# Altium schematic sheet MCU.SchDoc(MCU)
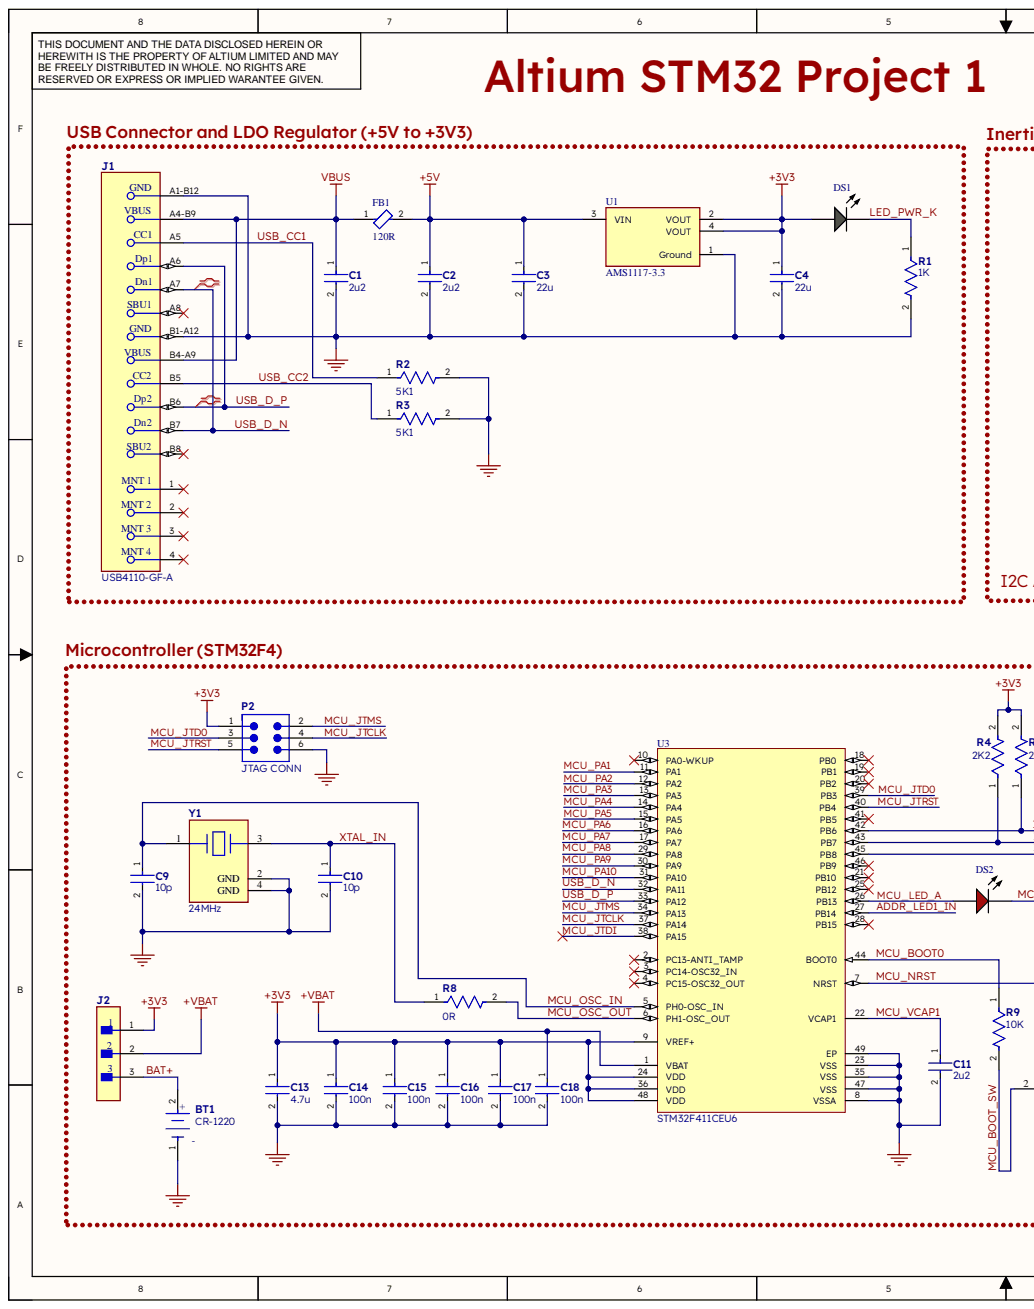
[diagram p0: MCU.SchDoc, left]
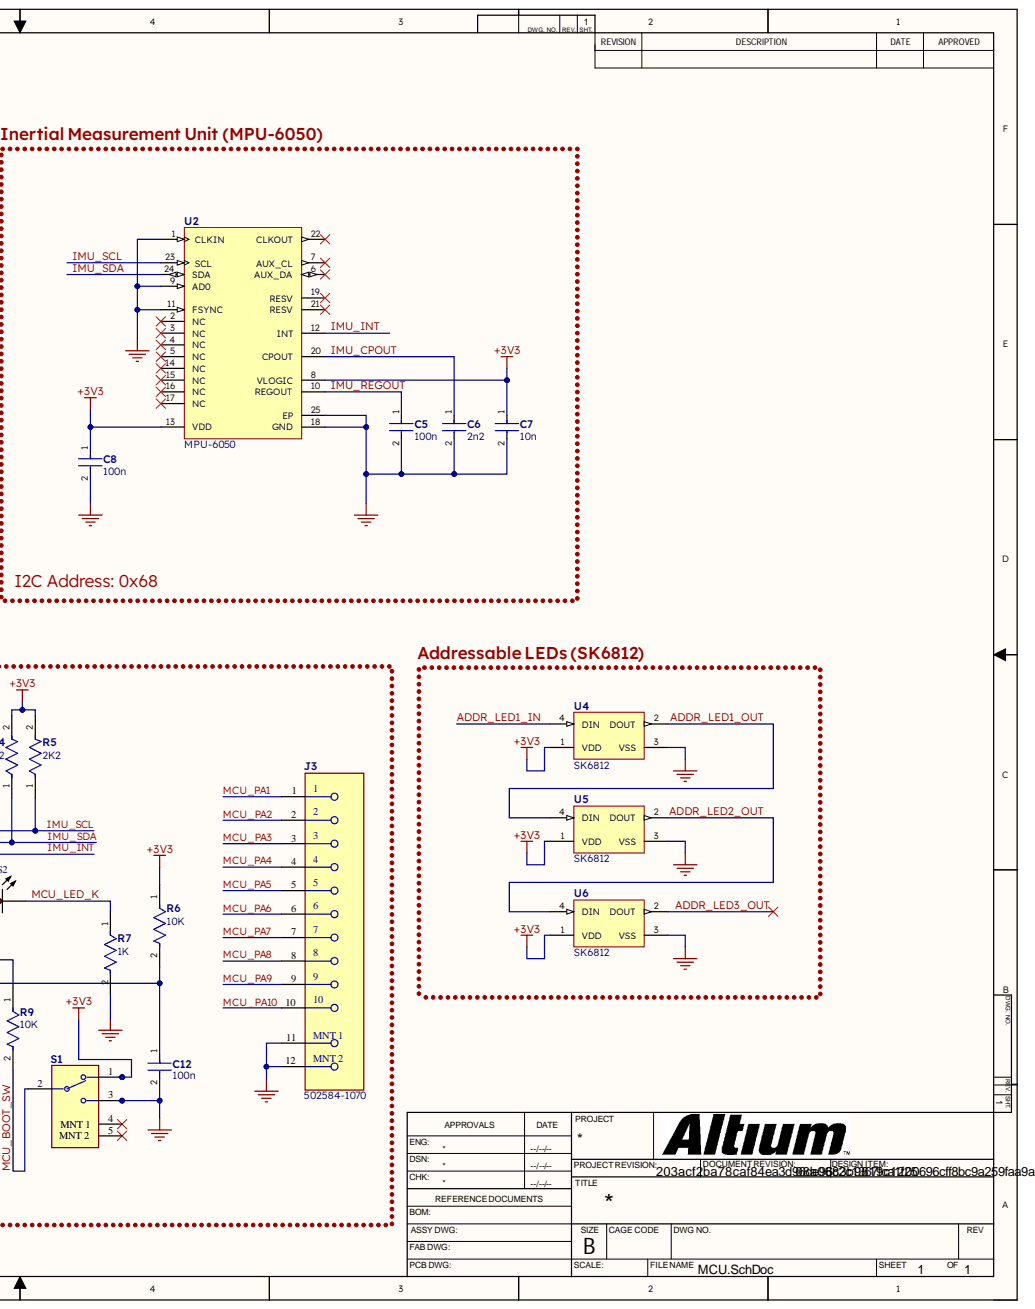
[diagram p1: MCU.SchDoc, right]

## Parts
BT1  CR-1220  [FP-S8411-45R-MFG]
C1  2u2  [FP-0805-L_2_01_0_2-W_1_25-IPC_B]
C2  2u2  [FP-0805-L_2_01_0_2-W_1_25-IPC_B]
C3  22u  [FP-CC0805-0_85-MFG]
C4  22u  [FP-CC0805-0_85-MFG]
C5  100n  [FP-CC0805-0_85-MFG]
C6  2n2  [FP-0805-L_2_01_0_2-W_1_25-IPC_B]
C7  10n  [FP-CC0805-0_85-MFG]
C8  100n  [FP-CC0805-0_85-MFG]
C9  10p  [FP-CC0805-0_85-MFG]
C10  10p  [FP-CC0805-0_85-MFG]
C11  2u2  [FP-0805-L_2_01_0_2-W_1_25-IPC_B]
C12  100n  [FP-CC0805-0_85-MFG]
C13  4.7u  [FP-0805-L_2_01_0_2-W_1_25-MFG]
C14  100n  [FP-CC0805-0_85-MFG]
C15  100n  [FP-CC0805-0_85-MFG]
C16  100n  [FP-CC0805-0_85-MFG]
C17  100n  [FP-CC0805-0_85-MFG]
C18  100n  [FP-CC0805-0_85-MFG]
DS1  1K  [AVAG-HSMX-C170_V]  (value not drawn)
DS2  LTST-C170KRKT  [LTST-C170KRKT_V]  (value not drawn)
FB1  120R  [FP-BLM21-0_2-t0_85-e0_5-IPC_A]
J1  USB4110-GF-A  [FP-USB4110-GF-A-MFG]
J2  5-146128-1  [FP-5-146128-1-MFG]  (value not drawn)
J3  502584-1070  [FP-502584-1070-MFG]
P2  JTAG CONN  [61000621121]
R1  1K  [FP-CRCW0805-e3-IPC_A]
R2  5K1  [FP-CRCW0805-e3-IPC_A]
R3  5K1  [FP-CRCW0805-e3-IPC_A]
R4  2K2  [FP-CRCW0805-e3-IPC_A]
R5  2K2  [FP-CRCW0805-e3-IPC_A]
R6  10K  [FP-CRCW0805-e3-IPC_A]
R7  1K  [FP-CRCW0805-e3-IPC_A]
R8  0R  [FP-CRCW0805-e3-IPC_A]
R9  10K  [FP-CRCW0805-e3-IPC_A]
S1  SS312SAH4-R  [FP-SS312SAH4-R-MFG]  (value not drawn)
U1  AMS1117-3.3  [FP-AMS1117-IPC_C]
U2  MPU-6050  [IS-QFN-24_V]
U3  STM32F411CEU6  [STM-UFQFPN48_L]
U4  SK6812  [ADA-RGB-1655_V]
U5  SK6812  [ADA-RGB-1655_V]
U6  SK6812  [ADA-RGB-1655_V]
Y1  24MHz  [FP-ABM8G-MFG]

## Nets
+3V3: C4.1 C7.1 C8.1 C13.1 C14.1 C15.1 C16.1 C17.1 DS1.1 J2.1 P2.1 R4.2 R5.2 R6.1 S1.1 U1.2(VOUT) U1.4(VOUT) U2.8(VLOGIC) U2.13(VDD) U3.9(VREF+) U3.24(VDD) U3.36(VDD) U3.48(VDD) U4.1(VDD) U5.1(VDD) U6.1(VDD)
+5V: C2.1 C3.1 FB1.2 U1.3(VIN)
+VBAT: C18.1 J2.2 U3.1(VBAT)
GND: BT1.1 C1.2 C2.2 C3.2 C4.2 C5.2 C6.2 C7.2 C8.2 C9.2 C10.2 C11.2 C12.2 C13.2 C14.2 C15.2 C16.2 C17.2 C18.2 J1.A1-B12 J1.B1-A12 J3.11 J3.12 P2.6 R1.2 R2.2 R3.2 R7.2 S1.3 U1.1(Ground) U2.1(CLKIN) U2.9(AD0) U2.11(FSYNC) U2.18(GND) U2.25(EP) U3.8(VSSA) U3.23(VSS) U3.35(VSS) U3.47(VSS) U3.49(EP) U4.3(VSS) U5.3(VSS) U6.3(VSS) Y1.2(GND) Y1.4(GND)
VBUS: C1.1 FB1.1 J1.A4-B9 J1.B4-A9
ADDR_LED1_IN: U3.27(PB14) U4.4(DIN)
ADDR_LED1_OUT: U4.2(DOUT) U5.4(DIN)
ADDR_LED2_OUT: U5.2(DOUT) U6.4(DIN)
ADDR_LED3_OUT: U6.2(DOUT)
BAT+: BT1.2 J2.3
IMU_CPOUT: C6.1 U2.20(CPOUT)
IMU_INT: U2.12(INT) U3.45(PB8)
IMU_REGOUT: C5.1 U2.10(REGOUT)
IMU_SCL: R5.1 U2.23(SCL) U3.42(PB6)
IMU_SDA: R4.1 U2.24(SDA) U3.43(PB7)
LED_PWR_K: DS1.2 R1.1
MCU_BOOT0: R9.1 U3.44(BOOT0)
MCU_BOOT_SW: R9.2 S1.2
MCU_JTCLK: P2.4 U3.37(PA14)
MCU_JTD0: P2.3 U3.39(PB3)
MCU_JTDI: U3.38(PA15)
MCU_JTMS: P2.2 U3.34(PA13)
MCU_JTRST: P2.5 U3.40(PB4)
MCU_LED_A: DS2.1 U3.26(PB13)
MCU_LED_K: DS2.2 R7.1
MCU_NRST: C12.1 R6.2 U3.7(NRST)
MCU_OSC_IN: C9.1 U3.5(PH0-OSC_IN) Y1.1
MCU_OSC_OUT: R8.2 U3.6(PH1-OSC_OUT)
MCU_PA1: J3.1 U3.11(PA1)
MCU_PA2: J3.2 U3.12(PA2)
MCU_PA3: J3.3 U3.13(PA3)
MCU_PA4: J3.4 U3.14(PA4)
MCU_PA5: J3.5 U3.15(PA5)
MCU_PA6: J3.6 U3.16(PA6)
MCU_PA7: J3.7 U3.17(PA7)
MCU_PA8: J3.8 U3.29(PA8)
MCU_PA9: J3.9 U3.30(PA9)
MCU_PA10: J3.10 U3.31(PA10)
MCU_VCAP1: C11.1 U3.22(VCAP1)
USB_CC1: J1.A5 R2.1
USB_CC2: J1.B5 R3.1
USB_D_N: J1.A7 J1.B7 U3.32(PA11)
USB_D_P: J1.A6 J1.B6 U3.33(PA12)
XTAL_IN: C10.1 R8.1 Y1.3

Not connected: J1 1 2 3 4 A8 B8; S1 4(MNT_1) 5(MNT_2); U2 2(NC) 3(NC) 4(NC) 5(NC) 6(AUX_DA) 7(AUX_CL) 14(NC) 15(NC) 16(NC) 17(NC) 19(RESV) 21(RESV) 22(CLKOUT); U3 2(PC13-ANTI_TAMP) 3(PC14-OSC32_IN) 4(PC15-OSC32_OUT) 10(PA0-WKUP) 18(PB0) 19(PB1) 20(PB2) 21(PB10) 25(PB12) 28(PB15) 41(PB5) 46(PB9)

## Library data of the parts
BT1 = CMP-15817-000007-1: BATTERY HOLDER COIN 12MM SMD; Number of Batteries: 1; Case/Package: SMD/SMT; Component Kind: Standard; Contact Plating: Bronze; Library Name: Altium Content Vault; Material: Polyphenylene; Max Operating Temperature: 105°C; Min Operating Temperature: -55°C; Mount: Surface Mount; Number of Cells: 1; Package Quantity: 500; Packaging: Tape and Reel; REACH SVHC: No SVHC; Termination: SMD/SMT
C1 C2 C6 C11 = CMP-2000-05810-2: General Purpose Ceramic Capacitor, 0805, 2.2uF, 10%, X5R, 15%, 10V; Dissipation Factor: 5%; Insulation Resistance: 500MR; Capacitance: 2.2uF; Case Code (Imperial): 0805; Case Code (Metric): 2012; Case/Package: 0805; Component Kind: Standard; Construction: Flat; Contact Plating: Tin; Depth: 1.25mm; Dielectric: X5R; Dielectric Material: Ceramic; Height: 1.4mm; Lead Free: Lead Free; Length: 2.01mm; Library Name: Altium Content Vault; Max Operating Temperature: 85°C; Min Operating Temperature: -55°C; Mount: Surface Mount; Number of Pins: 2; Package Quantity: 1; Packaging: Tape and Reel; Radiation Hardening: No; REACH SVHC: No SVHC; RoHS Compliant: Yes; Series: -; Size: 1.4mm; Termination: SMD/SMT; Thickness: 0.055inch; Tolerance: 10%; Voltage: 10V; Voltage Rating: 10V; Voltage Rating (DC): 10V; Width: 0.049inch
C3-C5 C7-C10 C12 C14-C18 = CMP-1036-04402-6: CAP CER 0.1UF 16V X7R 0805; Manufacturer: Yageo; Manufacturer Part Number: CC0805KRX7R7BB104; Capacitance: 100 nF; Material: Ceramic; Tolerance: 0.1; Value: 100 nF; Automotive: No; Category: Cap; Component Kind: Standard; ComponentLink2Description: Datasheet; ComponentLink2URL: http://www.yageo.com/documents/recent/UPY-GPHC_Y5V_6.3V-to-50V_8.pdf; Device Class L1: Passive Components; Device Class L2: Capacitors; Device Class L3: Ceramic Capacitors; Digikey Description: CAP CER 0.1UF 16V X7R 0805; Footprint Url: http://www.yageo.com/exep/pages/download/literatures/UPY-C_GEN_24.pdf; Height: 0.95mm; Ipc Land Pattern Name: CAPC200125X85; Lead Free: Yes; Library Name: Altium Content Vault; Mouser Description: Multilayer Ceramic Capacitors MLCC - SMD/SMT 100nF 16V X7R 10%; Octopart: https://octopart.com/cc0805krx7r7bb104-yageo-39467769; Package: CC0805-0.85; RoHS: TRUE; Temperature: -30°C to +85°C; Temperature Characteristic: X7R; Temperature Range High: +85°C; Temperature Range Low: -30°C; Voltage Rating: 16 V
C13 = CMP-2007-04518-2: General Purpose Ceramic Capacitor, 0805, 4.7uF, 10%, X7R, 15%, 16V; Dissipation Factor: 3.5%; Voltage: 10V; Capacitance: 4.7uF; Case Code (Imperial): 0805; Case Code (Metric): 2012; Case/Package: 0805; Component Kind: Standard; Construction: Flat; Contact Plating: Tin; Depth: 1.25mm; Dielectric: X7R; Height: 1.52mm; Lead Free: Lead Free; Length: 2.01mm; Library Name: Altium Content Vault; Max Operating Temperature: 125°C; Min Operating Temperature: -55°C; Mount: Surface Mount; Number of Pins: 2; Package Quantity: 1; Packaging: Tape and Reel; Radiation Hardening: No; REACH SVHC: No SVHC; RoHS Compliant: Yes; Series: -; Termination: SMD/SMT; Thickness: 0.06inch; Tolerance: 10%; Voltage Rating: 16V; Voltage Rating (DC): 16V; Width: 0.049inch
DS1 = CMP-2000-05062-1: Chip LED, Green, 2.2 V, -40 to 85 degC, 2-Pin SMD, Pb-Free, Tape and Reel; Manufacturer: Avago; Bulb Size: 1.25mmx1.4mm; Dominant Wavelength: 572nm; External Depth: 0.8mm; External Length / Height: 2mm; External Width: 1.25mm; Forward Current If: 20mA; Forward Voltage: 2.2V; Forward Voltage VF Max: 2.6V; LED Type: Standard; Lens Shape: Rectangular; Light Area Height: 1mm; Luminous Intensity: 15mcd; Luminous Intensity Min: 4mcd; No. of Pins: 2; Opto Mounting Orientation: Top; Peak Wavelength: 570nm; PIV Max: 5V; SVHC: NoSVHC(16-Jun-2014); Viewing Angle: 170deg; Component Kind: Standard; Datasheet URL: https://datasheet.ciiva.com/29280/180495-da-01-en-chip-led-hsmg-c170-gelb-gruen-29280971.pdf; Datasheet Version: Oct-08; Dominant Wavelength Typ: 572nm; Forward Current If Max: 20mA; LED Colour: Green; LED Mounting: SMD; Library Name: Altium Content Vault; Luminous Intensity Typ: 15mcd; Manufacturer URL: http://www.avagotech.com/; Mounting Type: SMD; MSL: MSL2A-4weeks; Operating Temperature Max: 85degC; Operating Temperature Min: -30degC; Operating Temperature Range: -30degCto+85degC; Opto Case Style: SMD; Package Description: 2-Pin Surface Mount Device, Body 2 x 1.25 mm, Height 0.8 mm; Package Reference: HSMX-C170; Packaging: CutTape; Peak Forward Current: 20mA; Storage Temperature Max: 85degC; Storage Temperature Min: -40degC; Voltage Vf Typ: 2.2V; Wavelength Typ: 570nm
DS2 = CMP-2000-07593-1: LED Red, 75 mW, 54 mcd, -55 to 85 degC, 2-Pin SMD, RoHS, Tape and Reel; Manufacturer: Lite-On; Case\/Package: 0805; Dominant Wavelength: 631nm; Forward Current: 30mA; Forward Voltage: 2V; Lens Colour: Colorless; Lens Style: Rectangular; Lens Transparency: Clear; Luminous Intensity: 54mcd; Number of Elements: 1; Power Dissipation: 75mW; Test Current: 20mA; Viewing Angle: 130degrees; Wavelength: 639nm; Case Code (Imperial): 0805; Case Code (Metric): 2012; Colour: Red; Component Kind: Standard; Datasheet URL: http://optoelectronics.liteon.com/upload/download/DS-22-99-0150/LTST-C170KRKT.pdf; Datasheet Version: Rev. D, 11/2014; Depth: 1.6mm; Height: 1.1mm; Illumination Colour: Red; Lead Free: LeadFree; Length: 3.2mm; Library Name: Altium Content Vault; Manufacturer URL: http://www.us.liteon.com/; Max Operating Temperature: 85degC; Min Operating Temperature: -55degC; Mount: SurfaceMount; Mounting Technology: SurfaceMount; Number of LEDs: 1; Number of Pins: 2; Package Description: 2-Pin Surface Mount Device, Body 2 x 1.25 mm; Package Quantity: 1; Package Reference: C170KRKT; Packaging: TapeandReel; Power Rating: 75mW; Radiation Hardening: No; RoHSCompliant: True; Width: 1.25mm
FB1 = CMP-0686-00716-3: FERRITE BEAD 120 OHM 0805 1LN; Current Rating: 800mA; DC Current: 200mA; DC Resistance (DCR): 100mR; Frequency: 100MHz; Material: Steel; Max Current Rating: 1A; Number of Circuits: 1; Resistance: 150mR; Shielding: Unshielded; Tolerance: 25%; Case Code (Imperial): 0805; Case Code (Metric): 2012; Case/Package: 0805; Component Kind: Standard; Core Material: Ferrite; DC Resistance-Max: 100mR; Depth: 1.25mm; Height: 0.85mm; Impedance: 120R; Lead Free: Lead Free; Length: 2mm; Library Name: Altium Content Vault; Max DC Current: 200mA; Max Operating Temperature: 125°C; Military Standard: Not; Min Operating Temperature: -55°C; Number of Lines: 1; Number of Pins: 2; Package Quantity: 1; Packaging: Tape and Reel; Radiation Hardening: No; REACH SVHC: No SVHC; Series: BLM21A; Termination: SMD/SMT; Test Frequency: 100MHz; Width: 1.25mm
J1 = CMP-245163-000002-1: CONN USB 2.0 TYPE-C R/A SMT; Manufacturer: GCT; Conn Orientation: Right Angle; Current Rating: 3/4.25/1.25/0.25A; Is Female: yes; Number Of Contacts: 16; Shielding: true; Standoff Height: 0.1mm; Usb Standard: USB 2.0; Voltage Rating: 20V; Automotive: No; Category: Conn; Component Kind: Standard; ComponentLink2Description: Datasheet; ComponentLink2URL: https://gct.co/files/drawings/usb4110.pdf; Contact Material: Gold,Nickel; Device Class L1: Connectors; Device Class L2: USB Connectors; Device Class L3: unset; Digikey Description: CONN USB 2.0 TYPE-C R/A SMT; Height: 3.46mm; Lead Free: Yes; Library Name: Altium Content Vault; Manufacturer Part Number: USB4110-GF-A; Octopart: https://octopart.com/usb4110-gf-a-gct-103941985; Package: 0; RoHS: TRUE; Temperature: -40°C to +85°C; Temperature Range High: +85°C; Temperature Range Low: -40°C
J2 = CMP-03369-000030-1: CONN HEADER SMD 3POS 2.54MM; Manufacturer: TE; Conn Gender: Male; Conn Orientation: Straight; Current Rating: 3A; Number Of Contacts: 3; Voltage Rating: 30V; Automotive: No; Category: Conn; Component Kind: Standard; ComponentLink2Description: Datasheet; ComponentLink2URL: https://www.te.com/global-en/product-5-146128-1.html; Contact Material: Gold,Nickel; Device Class L1: Connectors; Device Class L2: Headers and Wire Housings; Device Class L3: unset; Digikey Description: CONN HEADER SMD 3POS 2.54MM; Footprint Url: https://www.te.com/commerce/DocumentDelivery/DDEController?Action=srchrtrv&DocNm=146128&DocType=Customer+Drawing&DocLang=English; Height: 10.1092mm; Lead Free: Yes; Library Name: Altium Content Vault; Manufacturer Part Number: 5-146128-1; Mouser Description: AMPMODU 3 Position Surface Mount Single Row Straight 2.54 mm Breakaway Header; Number Of Rows: 1; Octopart: https://octopart.com/5-146128-1-te+connectivity-40948200; Package: HDR3; Pitch: 2.54mm; RoHS: TRUE; Temperature: -65°C to +125°C; Temperature Range High: +125°C; Temperature Range Low: -65°C
J3 = CMP-00257-18669480-1: 502584-1070 1.5 W/B Sgl ST RecAssy10CktEmbsTpPkg; Manufacturer Lifecycle Status: ACTIVE; Connector Type: Center Strip Contact, Receptacle; Current Rating: 2A; Gender: Receptacle; Glow Wire Compliant: Non-compliant; Number of Circuits: 10; Pitch: 1.5mm; Voltage Rating: 100V; Case/Package: SIL; Color: Beige; Component Kind: Standard; Contact Gender: Female; Contact Material: Copper, Tin; Contact Plating: Tin; Country of Origin: JP; Depth: 6.35mm; Fastening Type: Latch; Height: 7.5mm; Housing Color: Beige; Housing Material: Resin; Length: 19.3mm; Library Name: Altium Content Vault; Lifecycle Status: Production; Max Operating Temperature: 85°C; Min Operating Temperature: -40°C; Mount: Surface Mount; NexarPartId: 18669480; Number of Contacts: 10; Number of Pins: 10; Number of Positions: 10; Number of Rows: 1; Orientation: Vertical; Packaging: Cut Tape; Radiation Hardening: No; REACH SVHC: Unknown; RoHS: Compliant; Termination: Solder
P2 = CMP-1502-01024-2: NexarPartId: 32855428; Connector Type: Male Pin; Contact Resistance: 20mΩ; Current Rating: 3A; Gender: Male; Number of Contacts: 6; Operating Supply Voltage: 250V; Pitch: 2.54mm; Color: Black; Component Kind: Standard; Contact Material: Copper; Contact Mating Length: 5.9944mm; Contact Plating: Gold; Lead Pitch: 2.54mm; Library Name: Altium Content Vault; Mount: Surface Mount; Number of Pins: 6; Number of Positions: 6; Number of Rows: 2; Orientation: Vertical; REACH SVHC: Unknown; RoHS: Compliant; Row Spacing: 2.54mm; Termination: Solder
R1-R9 = CMP-2001-04908-2: RES Thick Film, 10kΩ, 1%, 0.125W, 100ppm/°C, 0805; Power Rating: 125mW; Resistance: 10kR; Voltage Rating: 150V; Case Code (Imperial): 0805; Case Code (Metric): 2012; Case/Package: 0805; Component Kind: Standard; Composition: Thick Film; Contact Plating: Tin; Depth: 1.25mm; Height: 0.45mm; Lead Free: Lead Free; Length: 2mm; Library Name: Altium Content Vault; Max Operating Temperature: 155°C; Military Standard: Not; Min Operating Temperature: -55°C; Package Quantity: 5000; Packaging: Tape and Reel; Radiation Hardening: No; Temperature Coefficient: 100ppm/°C; Termination: SMD/SMT; Tolerance: 1%; Voltage Rating (DC): 150V; Weight: 0.000194oz; Width: 1.25mm
S1 = CMP-31746-000001-1: SWITCH SLIDE SPDT 0.4VA 28V; Actuator Length: 2mm; Actuator Material: Polyphenylene; Actuator Type: Standard; Contact Style: Shorting; Electrical Life: 10000Cycles; Max Current Rating: 100mA; Switch Function: On-On; Case/Package: SMD/SMT; Component Kind: Standard; Contact Material: Bronze; Contact Plating: Gold; Depth: 7.45mm; Housing Material: Bronze; Lead Free: Lead Free; Length: 10.5mm; Library Name: Altium Content Vault; Max Operating Temperature: 85°C; Max Voltage Rating (AC): 28V; Max Voltage Rating (DC): 28V; Mechanical Life: 10000Cycles; Min Operating Temperature: -25°C; Mount: Surface Mount; Orientation: Right Angle; Package Quantity: 1; Packaging: Tape and Reel; REACH SVHC: No SVHC; RoHS Compliant: Yes; Series: SS3; Switch Travel: 2mm; Termination: Gull Wing; Throw Configuration: SPDT; Voltage Rating (AC): 28V; Voltage Rating (DC): 28V
U1 = CMP-209535-000001-1: LDO Voltage Regulators 1A, 3.3V; Manufacturer: Advanced Monolithic Systems; Dropout Voltage: 1.1V; Max Junction Temp: +125°C; Max Power Dissipation: 1.2W; Max Supply Voltage: 15V; Nominal Supply Current: 5mA; Number Of Outputs: 1; Output Type: Fixed; Standoff Height: 0.64mm; Automotive: No; Category: IC; Component Kind: Standard; ComponentLink2Description: Datasheet; ComponentLink2URL: http://www.advanced-monolithic.com/pdf/ds1117.pdf; Device Class L1: Integrated Circuits (ICs); Device Class L2: Power Management ICs; Device Class L3: Voltage Regulators - Linear; Height: 1.8mm; Ipc Land Pattern Name: SOT229P700X180-4; Lead Free: Yes; Library Name: Altium Content Vault; Manufacturer Part Number: AMS1117-3.3; Octopart: https://octopart.com/ams1117-3.3-advanced+monolithic+systems-25899300; Output Current: 1A; Output Voltage: 3.3V; Package: AMS1117; RoHS: TRUE; Temperature: -40°C to +125°C; Temperature Range High: +125°C; Temperature Range Low: -40°C
U2 = CMP-1753-00002-2: 3-Axis Gyroscope, Accelerometer and a Digital Motion Processor(TM) (DMP), 2.375 to 3.46 V, -40 to 85 degC, 24-Pin QFN, RoHS, Tape and Reel; Manufacturer: InvenSense; Dynamic Catalog: MPU-6000™Series; Featured Product: MPU-60506-Axis,IntegratedI²CSolution; Other Names: 1428-1007-2  MPU6050; Sensor Type: MotionTracking; Category: Sensors,Transducers; Component Kind: Standard; Datasheet URL: http://datasheet.octopart.com/MPU-6050-InvenSense-datasheet-14421344.pdf; Datasheet Version: Rev. 3.3, 05/2012; Family: Multifunction; Library Name: Altium Content Vault; Manufacturer URL: http://www.invensense.com/; Online Catalog: MPU-6000™Series; Output Type: Digital; Standard Package: 5,000; Unit PriceUSD: Digital
U3 = CMP-0243-00165-1: ARM Cortex-M4 32-bit MCU+FPU, 512 KB Flash, 128 KB RAM, 36 I/Os, 48-Pin UFQFPN, -40 to 85 degC, Tray; FLASH Size (Prog) (kB): 512; I/Os (High Current): 36; Internal RAM Size (kB): 128; Operating Frequency(F) (Processor speed) (MHz): 100; Supply Voltage(Vcc) min (V): 1.7; Component Kind: Standard; ComponentLink1Description: Manufacturer URL; ComponentLink1URL: http://www.st.com/; ComponentLink2Description: Datasheet; ComponentLink2URL: http://www.st.com/st-web-ui/static/active/en/resource/technical/document/datasheet/DM00115249.pdf; Core: ARM Cortex-M4; DatasheetVersion: Rev. 6; Library Name: Altium Content Vault; Mounting Technology: Surface Mount; Package Description: UFQFPN48; Package Reference: 48-Pin Ultra Thin Fine Pitch Quad Flat No-Lead, 7 x 7 x 0.6 mm Body, 0.5 mm Pitch, Exposed Pad; Packing: Tray
U4-U6 = CMP-2000-05026-1: Intelligent Control LED Integrated Light Source, 3.5 to 5.3 V, -25 to 80 degC, 4-Pin SMD, RoHS, Tape and Reel; Manufacturer: Adafruit-Industries-LLC; Configuration: Discrete; Number of LEDs: 10; Utilized LED: WS2812(5050); Category: Optoelectronics; Color: Red,Green,Blue(RGB); Component Kind: Standard; Controller Type: -; Datasheet URL: http://datasheet.octopart.com/ADA1655-Adafruit-Industries-datasheet-32028139.pdf; Datasheet Version: May-13; Family: Addressable,Specialty; Interface: -; Library Name: Altium Content Vault; Manufacturer URL: https://www.adafruit.com/; Mounting Type: SurfaceMount; Package Description: 4-Pin Surface Mount Device, Body 5 x 5 mm; Package Reference: 1655; Series: Neopixel; Size / Dimension: 5.00mmLx5.00mmW
Y1 = CMP-27762-015229-1: Crystal 24MHz ±30ppm (Tol) ±30ppm (Stability) 10pF FUND 60Ω 4-Pin SMD T/R; ESR (Equivalent Series Resistance): 60R; Case/Package: CSMD; Component Kind: Standard; Depth: 2.5mm; Frequency: 24MHz; Frequency Stability: 30ppm; Frequency Tolerance: 30ppm; Height: 1mm; Lead Free: Lead Free; Length: 3.2mm; Library Name: Altium Content Vault; Load Capacitance: 10pF; Max Operating Temperature: 70°C; Min Operating Temperature: -20°C; Mount: Surface Mount; Number of Pins: 4; Operating Frequency: 24 MHz; Package Quantity: 1000; Packaging: Tape and Reel; Series: ABM8G; Series Resistance: 60R; Termination: SMD/SMT; Tolerance: 30ppm; Width: 2.5mm
